# Altium schematic sheet TurboSanta_Schematic.SchDoc(TurboSanta_Schematic)
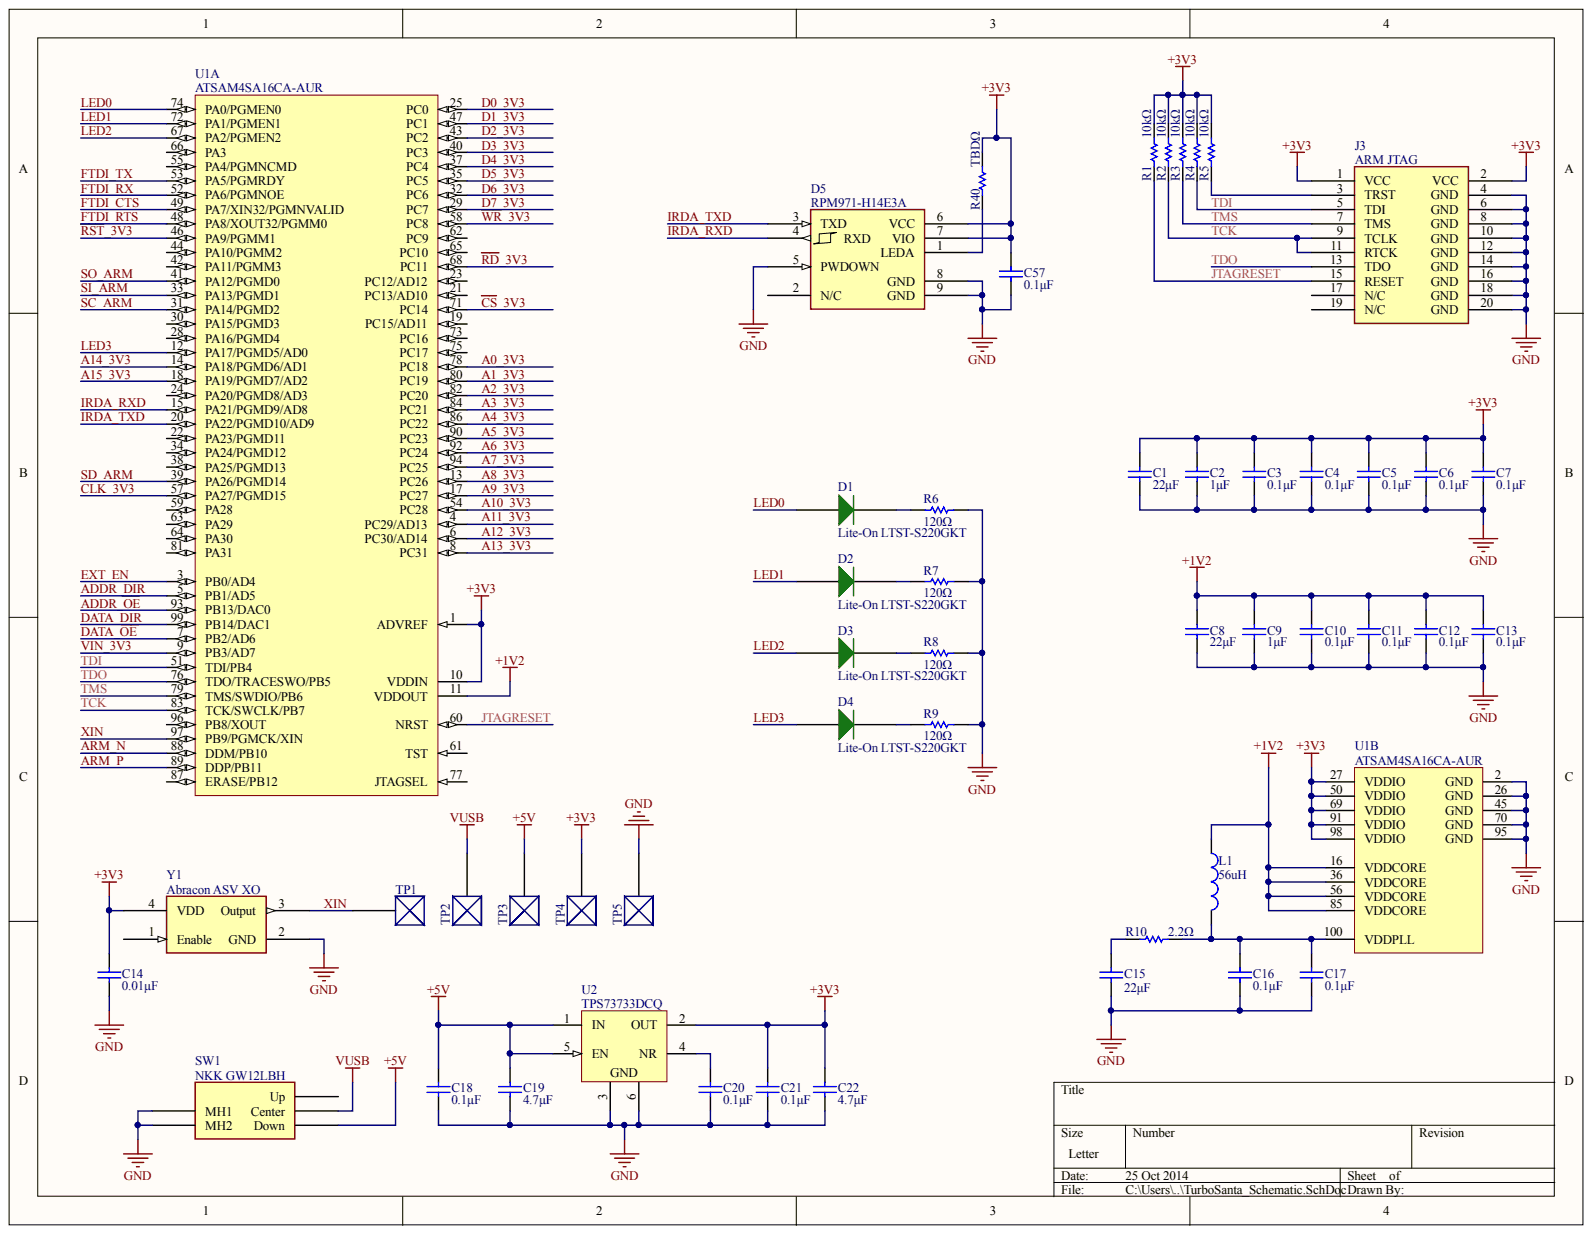
[diagram p0: TurboSanta_Schematic.SchDoc]

## Parts
C1  value 22μF  [C0805]  (hidden comment: Cap)
C2  value 1μF  [C0805]  (hidden comment: Cap)
C3  value 0.1μF  [C0805]  (hidden comment: Cap)
C4  value 0.1μF  [C0805]  (hidden comment: Cap)
C5  value 0.1μF  [C0805]  (hidden comment: Cap)
C6  value 0.1μF  [C0805]  (hidden comment: Cap)
C7  value 0.1μF  [C0805]  (hidden comment: Cap)
C8  value 22μF  [C0805]  (hidden comment: Cap)
C9  value 1μF  [C0805]  (hidden comment: Cap)
C10  value 0.1μF  [C0805]  (hidden comment: Cap)
C11  value 0.1μF  [C0805]  (hidden comment: Cap)
C12  value 0.1μF  [C0805]  (hidden comment: Cap)
C13  value 0.1μF  [C0805]  (hidden comment: Cap)
C14  value 0.01μF  [C0805]  (hidden comment: Cap)
C15  value 22μF  [C0805]  (hidden comment: Cap)
C16  value 0.1μF  [C0805]  (hidden comment: Cap)
C17  value 0.1μF  [C0805]  (hidden comment: Cap)
C18  value 0.1μF  [C0805]  (hidden comment: Cap)
C19  value 4.7μF  [C0805]  (hidden comment: Cap)
C20  value 0.1μF  [C0805]  (hidden comment: Cap)
C21  value 0.1μF  [C0805]  (hidden comment: Cap)
C22  value 4.7μF  [C0805]  (hidden comment: Cap)
C57  value 0.1μF  [C0805]  (hidden comment: Cap)
D1  Lite-On LTST-S220GKT  [Lite-On LTST-S220GKT]
D2  Lite-On LTST-S220GKT  [Lite-On LTST-S220GKT]
D3  Lite-On LTST-S220GKT  [Lite-On LTST-S220GKT]
D4  Lite-On LTST-S220GKT  [Lite-On LTST-S220GKT]
D5  RPM971-H14E3A  [ROHM IRDA]
J3  ARM JTAG  [3M 2520-6002UB]
L1  value 56uH  [C0805]  (hidden comment: Inductor)
R1  value 10kΩ  [C0805]  (hidden comment: Res1)
R2  value 10kΩ  [C0805]  (hidden comment: Res1)
R3  value 10kΩ  [C0805]  (hidden comment: Res1)
R4  value 10kΩ  [C0805]  (hidden comment: Res1)
R5  value 10kΩ  [C0805]  (hidden comment: Res1)
R6  value 120Ω  [C0805]  (hidden comment: Res1)
R7  value 120Ω  [C0805]  (hidden comment: Res1)
R8  value 120Ω  [C0805]  (hidden comment: Res1)
R9  value 120Ω  [C0805]  (hidden comment: Res1)
R10  value 2.2Ω  [C0805]  (hidden comment: Res1)
R40  value TBDΩ  [C0805]  (hidden comment: Res1)
SW1  NKK GW12LBH  [NKK Switches Series GW]
TP1  Testpoint  [TESTPOINT]  (value not drawn)
TP2  Testpoint  [TESTPOINT]  (value not drawn)
TP3  Testpoint  [TESTPOINT]  (value not drawn)
TP4  Testpoint  [TESTPOINT]  (value not drawn)
TP5  Testpoint  [TESTPOINT]  (value not drawn)
U1  ATSAM4SA16CA-AUR  [LQFP100_N]  (parts A,B)
U2  TPS73733DCQ  [TI-DCQ6_N]
Y1  Abracon ASV XO  [Abracon ASV XO]

## Nets
+1V2: C8.2 C9.2 C10.2 C11.2 C12.2 C13.2 L1.1 U1.11(VDDOUT) U1.16(VDDCORE) U1.36(VDDCORE) U1.56(VDDCORE) U1.85(VDDCORE)
+3V3: C1.2 C2.2 C3.2 C4.2 C5.2 C6.2 C7.2 C14.2 C21.2 C22.2 C57.2 D5.6(VCC) D5.7(VIO) J3.1(VCC) J3.2(VCC) R1.1 R2.1 R3.1 R4.1 R5.1 R40.1 TP4.1 U1.1(ADVREF) U1.10(VDDIN) U1.27(VDDIO) U1.50(VDDIO) U1.69(VDDIO) U1.91(VDDIO) U1.98(VDDIO) U2.2(OUT) Y1.4(VDD)
+5V: C18.2 C19.2 SW1.6(Down) TP3.1 U2.1(IN) U2.5(EN)
GND: C1.1 C2.1 C3.1 C4.1 C5.1 C6.1 C7.1 C8.1 C9.1 C10.1 C11.1 C12.1 C13.1 C14.1 C15.2 C16.1 C17.1 C18.1 C19.1 C20.1 C21.1 C22.1 C57.1 D5.5(PWDOWN) D5.8(GND) D5.9(GND) J3.4(GND) J3.6(GND) J3.8(GND) J3.10(GND) J3.12(GND) J3.14(GND) J3.16(GND) J3.18(GND) J3.20(GND) R6.2 R7.2 R8.2 R9.2 SW1.MH1 SW1.MH2 TP5.1 U1.2(GND) U1.26(GND) U1.45(GND) U1.70(GND) U1.95(GND) U2.3 U2.6 Y1.2(GND)
VUSB: SW1.5(Center) TP2.1
A0_3V3: U1.78(PC18)
A1_3V3: U1.80(PC19)
A2_3V3: U1.82(PC20)
A3_3V3: U1.84(PC21)
A4_3V3: U1.86(PC22)
A5_3V3: U1.90(PC23)
A6_3V3: U1.92(PC24)
A7_3V3: U1.94(PC25)
A8_3V3: U1.13(PC26)
A9_3V3: U1.17(PC27)
A10_3V3: U1.54(PC28)
A11_3V3: U1.4(PC29/AD13)
A12_3V3: U1.6(PC30/AD14)
A13_3V3: U1.8(PC31)
A14_3V3: U1.14(PA18/PGMD6/AD1)
A15_3V3: U1.18(PA19/PGMD7/AD2)
ADDR_DIR: U1.5(PB1/AD5)
ADDR_~{OE}: U1.93(PB13/DAC0)
ARM_N: U1.88(DDM/PB10)
ARM_P: U1.89(DDP/PB11)
~{CS}_3V3: U1.71(PC14)
CLK_3V3: U1.57(PA27/PGMD15)
D0_3V3: U1.25(PC0)
D1_3V3: U1.47(PC1)
D2_3V3: U1.43(PC2)
D3_3V3: U1.40(PC3)
D4_3V3: U1.37(PC4)
D5_3V3: U1.35(PC5)
D6_3V3: U1.32(PC6)
D7_3V3: U1.29(PC7)
DATA_DIR: U1.99(PB14/DAC1)
DATA_~{OE}: U1.7(PB2/AD6)
EXT_EN: U1.3(PB0/AD4)
FTDI_CTS: U1.49(PA7/XIN32/PGMNVALID)
FTDI_RTS: U1.48(PA8/XOUT32/PGMM0)
FTDI_RX: U1.52(PA6/PGMNOE)
FTDI_TX: U1.53(PA5/PGMRDY)
IRDA_RXD: D5.4 U1.15(PA21/PGMD9/AD8)
IRDA_TXD: D5.3(TXD) U1.20(PA22/PGMD10/AD9)
JTAGRESET: J3.15(RESET) R1.2 U1.60(NRST)
LED0: D1.1 U1.74(PA0/PGMEN0)
LED1: D2.1 U1.72(PA1/PGMEN1)
LED2: D3.1 U1.67(PA2/PGMEN2)
LED3: D4.1 U1.12(PA17/PGMD5/AD0)
~{RD}_3V3: U1.68(PC11)
~{RST}_3V3: U1.46(PA9/PGMM1)
SC_ARM: U1.31(PA14/PGMD2)
SD_ARM: U1.39(PA26/PGMD14)
SI_ARM: U1.33(PA13/PGMD1)
SO_ARM: U1.41(PA12/PGMD0)
TCK: J3.9(TCLK) J3.11(RTCK) R2.2 U1.83(TCK/SWCLK/PB7)
TDI: J3.5(TDI) R4.2 U1.51(TDI/PB4)
TDO: J3.13(TDO) U1.76(TDO/TRACESWO/PB5)
TMS: J3.7(TMS) R3.2 U1.79(TMS/SWDIO/PB6)
VIN_3V3: U1.9(PB3/AD7)
~{WR}_3V3: U1.58(PC8)
XIN: TP1.1 U1.97(PB9/PGMCK/XIN) Y1.3(Output)
NetC15_1: C15.1 R10.2
NetC16_2: C16.2 C17.2 L1.2 R10.1 U1.100(VDDPLL)
NetC20_2: C20.2 U2.4(NR)
NetD1_2: D1.2 R6.1
NetD2_2: D2.2 R7.1
NetD3_2: D3.2 R8.1
NetD4_2: D4.2 R9.1
NetD5_1: D5.1(LEDA) R40.2
NetJ3_3: J3.3(TRST) R5.2

Not connected: D5 2(N/C); J3 17(N/C) 19(N/C); SW1 4(Up); U1 19(PC15/AD11) 21(PC13/AD10) 22(PA23/PGMD11) 23(PC12/AD12) 24(PA20/PGMD8/AD3) 28(PA16/PGMD4) 30(PA15/PGMD3) 34(PA24/PGMD12) 38(PA25/PGMD13) 42(PA11/PGMM3) 44(PA10/PGMM2) 55(PA4/PGMNCMD) 59(PA28) 61(TST) 62(PC9) 63(PA29) 64(PA30) 65(PC10) 66(PA3) 73(PC16) 75(PC17) 77(JTAGSEL) 81(PA31) 87(ERASE/PB12) 96(PB8/XOUT); Y1 1(Enable)

## Library data of the parts
C1-C22 C57 = Cap: Capacitor; Component Kind: Standard; LatestRevisionDate: 17-Jul-2002; LatestRevisionNote: Re-released for DXP Platform.; Library Name: Miscellaneous Devices.IntLib; PackageDescription: Radial Cap, Thru-Hole; 2 Leads; 0.3 in Pin Spacing; PackageReference: RAD-0.3; Published: 8-Jun-2000; Signal Integrity: Cap; Simulation: CAP; Publisher: Altium Limited
D1-D4 = Lite-On LTST-S220GKT: LED RT ANGLE GREEN CLR 0805; Component Kind: Standard; Library Name: TS-Schematic.SchLib
D5 = RPM971-H14E3A: MODULE IRDA 5MBPS 8SMD; Component Kind: Standard; Library Name: TS-Schematic.SchLib
J3 = 20-Pin ARM JTAG Programming Header: Standard 20-Pin Pinout; Component Kind: Standard; Library Name: TS-Schematic.SchLib
L1 = Inductor: Code_IEC: 1005; Code_JEITA: 0402; Component Kind: Standard; Description: Inductor; LatestRevisionDate: 29-May-2009; LatestRevisionNote: IPC-7351 Footprint Added.; Library Name: Miscellaneous Devices.IntLib; PackageDescription: Chip Inductor; PackageReference: 0402-A; Published: 8-Jun-2000; Signal Integrity: Inductor; Simulation: INDUCTOR; Publisher: Altium Limited
R1-R10 R40 = Res1: Resistor; Component Kind: Standard; LatestRevisionDate: 17-Jul-2002; LatestRevisionNote: Re-released for DXP Platform.; Library Name: Miscellaneous Devices.IntLib; PackageDescription: Axial Device, Thru-Hole; 2 Leads; 0.3 in Pin Spacing; PackageReference: AXIAL-0.3; Published: 8-Jun-2000; Signal Integrity: Res; Simulation: RESISTOR; Publisher: Altium Limited
SW1 = NKK GW12RHH: SWITCH ROCKER SPDT 0.4VA 28V; Component Kind: Standard; Library Name: TS-Schematic.SchLib
U1 = ATSAM4S16CA-AU: AT91 ARM Cortex-M4 32-bit Microcontroller, 1MB Flash, 128KB SRAM, USB, 79 User I/Os, 100-Pin LQFP, Industrial Grade (-40°C to 105°C), Pb-Free; Code_JEDEC: MS-026-BED; Component Kind: Standard; ComponentLink1Description: Manufacturer URL; ComponentLink1URL: http://www.atmel.com/; ComponentLink2Description: SAM4S Series Datasheet; ComponentLink2URL: http://www.atmel.com/dyn/resources/prod_documents/11100.pdf; ComponentLink3Description: SAM4S Series Summary; ComponentLink3URL: http://www.atmel.com/dyn/resources/prod_documents/11100S.pdf; DatasheetVersion: revA, Oct-2011; Library Name: Atmel Microcontroller ARM.IntLib; PackageDescription: 100-Pin Thin Quad Flat Pack 0.5 mm Pitch; PackageReference: LQFP100; PackageVersion: Apr-2006
U2 = TPS73733DCQ: Single Output LDO, 1 A, Fixed 3.3 V Output, 2.2 to 5.5 V Input, with Reverse Current Protection, 6-pin SOT-223 (DCQ), -40 to 125 degC, Green (RoHS & no Sb/Br); Manufacturer: Texas Instruments; Accuracy(%): 3; Fixed Output Options(V): 3.3; Iout(Max)(A): 1; Iq(Typ)(mA): 0.3; Rating: Catalog; SubFamily: Single Channel LDO; Vdo(Typ)(mV): 130; Vin(Max)(V): 5.5; Vin(Min)(V): 2.2; Component Kind: Standard; ComponentLink1Description: Manufacturer URL; ComponentLink1URL: http://www.ti.com/; ComponentLink2Description: Datasheet; ComponentLink2URL: http://www.ti.com/general/docs/lit/getliterature.tsp?genericPartNumber=TPS73733&fileType=pdf; ComponentLink3Description: Package Specification; ComponentLink3URL: http://www.ti.com/litv/pdf/mpds098c; DatasheetVersion: SBVS067O; Eco-Plan: Green (RoHS & no Sb/Br); Library Name: TI Power Management Linear Regulator Single Channel LDO (400-7500mA).IntLib; Mounting Technology: Surface Mount; PackageDescription: 6-Pin Plastic Small Outline, Body 3.5 x 6.5 mm, Pitch 1.27 mm; PackageReference: DCQ6; PackageVersion: 4202109/D; PartNumber: TPS73733DCQ; Supplier 1: Digi-Key; Supplier 2: Farnell; Supplier 3: Newark; Supplier 4: Mouser; Supplier Part Number 1: 296-24814-5-ND; Supplier Part Number 2: 1703384; Supplier Part Number 3: 58M1296; Supplier Part Number 4: 595-TPS73733DCQ; Vout Adj(Max)(V): 3.3; Vout Adj(Min)(V): 3.3
Y1 = Abracon ASV XO: OSCILLATOR 16.000MHZ 3.3V SMD; Component Kind: Standard; Library Name: TS-Schematic.SchLib
TP1-TP5 = Testpoint: Component Kind: Standard; Library Name: TS-Schematic.SchLib
